# Altium schematic sheet [4]-MCU_POWER.SchDoc([4]-MCU_POWER)
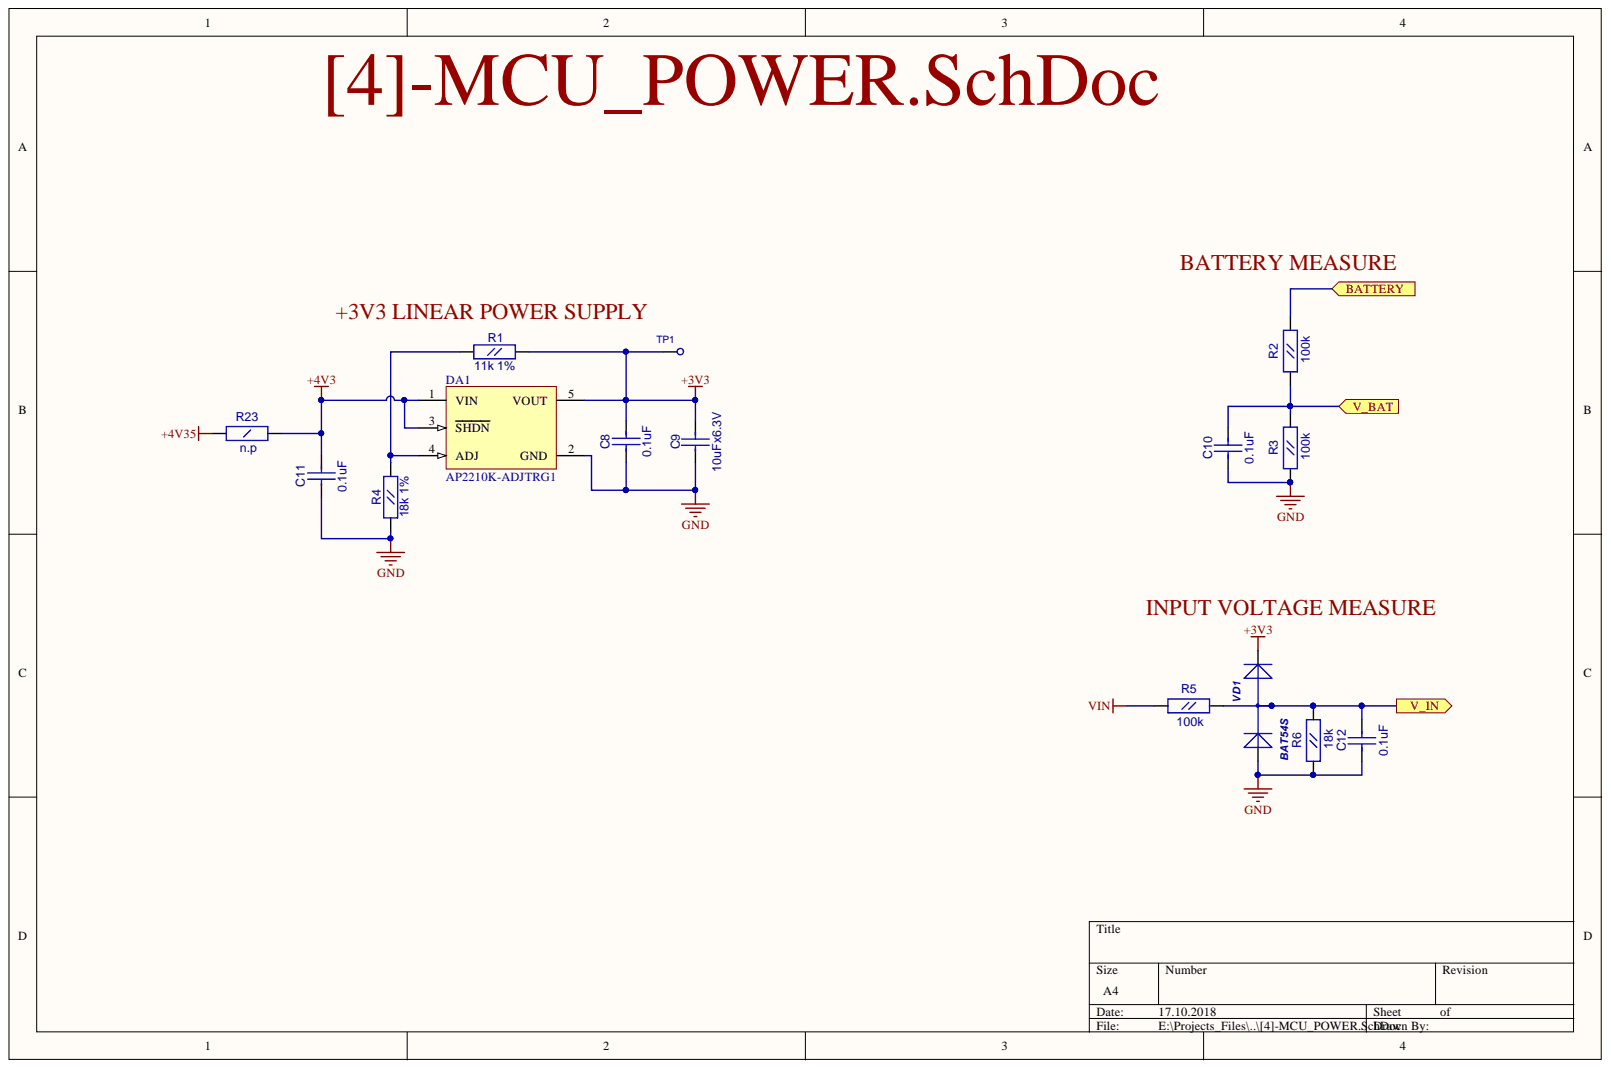
[diagram p0: [4]-MCU_POWER.SchDoc]

## Parts
C8  0.1uF  [CC0603]
C9  10uFx6.3V  [CC0805]
C10  0.1uF  [CC0603]
C11  0.1uF  [CC0603]
C12  0.1uF  [CC0603]
DA1  AP2210K-ADJTRG1  [SOT23-5]
R1  11k 1%  [RC0603]
R2  100k  [RC0603]
R3  100k  [RC0603]
R4  18k 1%  [RC0603]
R5  100k  [RC0603]
R6  18k  [RC0603]
R23  n.p  [RC1206]
TP1  TESTPOINT1  [TESTPOINT1]  (value not drawn)
VD1  BAT54S  [SOT-23(GSD)]

## Ports
BATTERY, V_BAT, V_IN

## Nets
+3V3: C8.1 C9.2 DA1.5(VOUT) R1.2 TP1.1 VD1.2
+4V3: C11.1 DA1.1(VIN) DA1.3(SHDN) R23.2
+4V35: R23.1
GND: C8.2 C9.1 C10.1 C11.2 C12.1 DA1.2(GND) R3.1 R4.1 R6.1 VD1.1
VIN: R5.1
BATTERY: R2.2 ; port BATTERY
V_BAT: C10.2 R2.1 R3.2 ; port V_BAT
V_IN: C12.2 R5.2 R6.2 VD1.3 ; port V_IN
NetDA1_4: DA1.4(ADJ) R1.1 R4.2

## Library data of the parts
C8 C10-C12 = CC0603: Value: 0.1uF; Description: CC0603; Component Kind: Standard; Library Name: gprs_modem_2.SchLib
C9 = CC0805: Value: 10uFx6.3V; Description: CC0805; Component Kind: Standard; Library Name: gprs_modem_2.SchLib
DA1 = MCP1824T-ADJE/OT: 300 mA, Low Voltage, Low Quiescent Current LDO Regulator, 5-Pin SOT-223, Extended Temperature, Tape and Reel; Published: 29-Jan-2009; PackageVersion: revAW, Oct-2008; PackageReference: SOT-223-DC5; PackageDescription: 5-Lead Plastic Small Outline Transistor (DC) [SOT-223]; DatasheetVersion: revA, Aug-2007; ComponentLink3URL: http://ww1.microchip.com/downloads/en/PackagingSpec/00049AW.pdf; ComponentLink3Description: Packaging Specification; ComponentLink2URL: http://ww1.microchip.com/downloads/en/DeviceDoc/22070a.pdf; ComponentLink2Description: Datasheet; ComponentLink1URL: http://www.microchip.com; ComponentLink1Description: Manufacturer Link; Component Kind: Standard; Publisher: Altium Limited; Library Name: gprs_modem_2.SchLib
R1-R6 = RC0603: Description: RC0603; Component Kind: Standard; Library Name: gprs_modem_2.SchLib
  R1: Value: 11k 1%
  R2 R3 R5: Value: 100k
  R4: Value: 18k 1%
  R6: Value: 18k
R23 = RC1206: Value: n.p; Description: RC1206; Component Kind: Standard; Library Name: fizik_lib.SchLib
VD1 = BAT54S: 1.0 Ampere General Purpose Rectifier; ComponentField6: Published 8-Jun-2000, Modified 11-July-2000: SIM Model Added.; Simulation: DIODE; Component Kind: Standard; Library Name: gprs_modem_2.SchLib
TP1 = TESTPOINT1: Description: TESTPOINT1; Component Kind: Standard; Library Name: fizik_lib.SchLib
